# Altium schematic sheet [2] - MCU STM32F030CCTx.sch
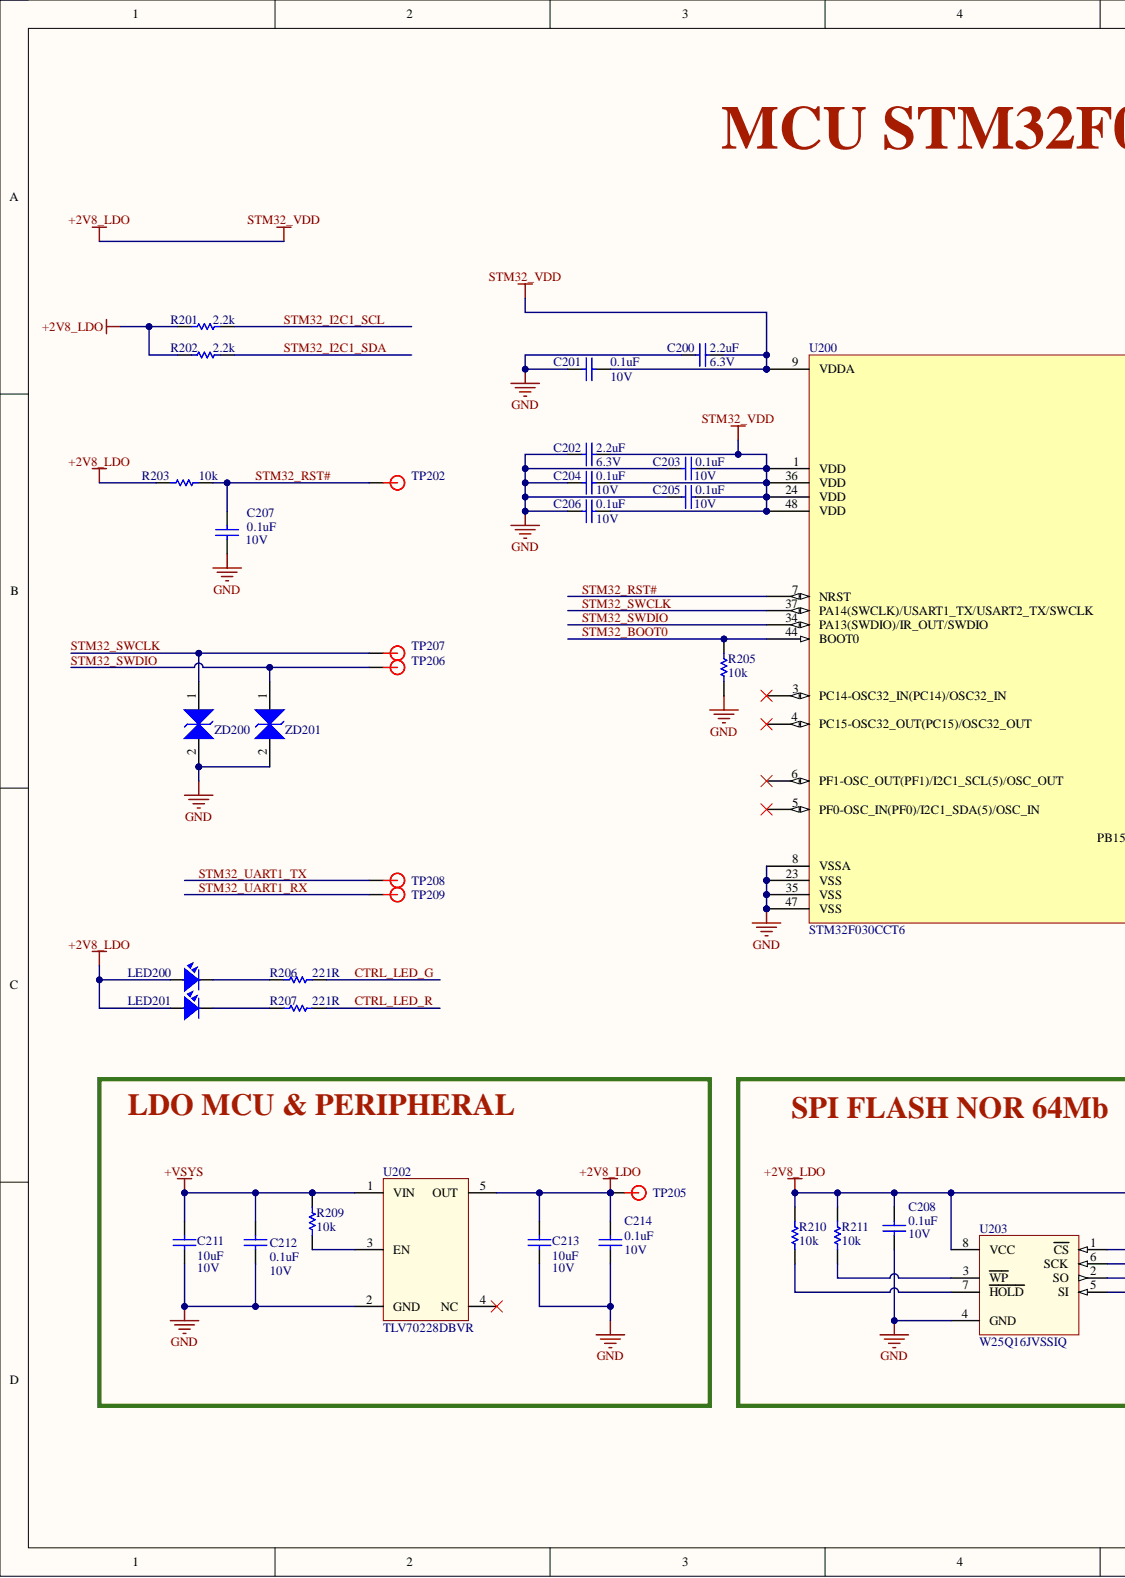
[diagram p0: [2] - MCU STM32F030CCTx.sch, left]
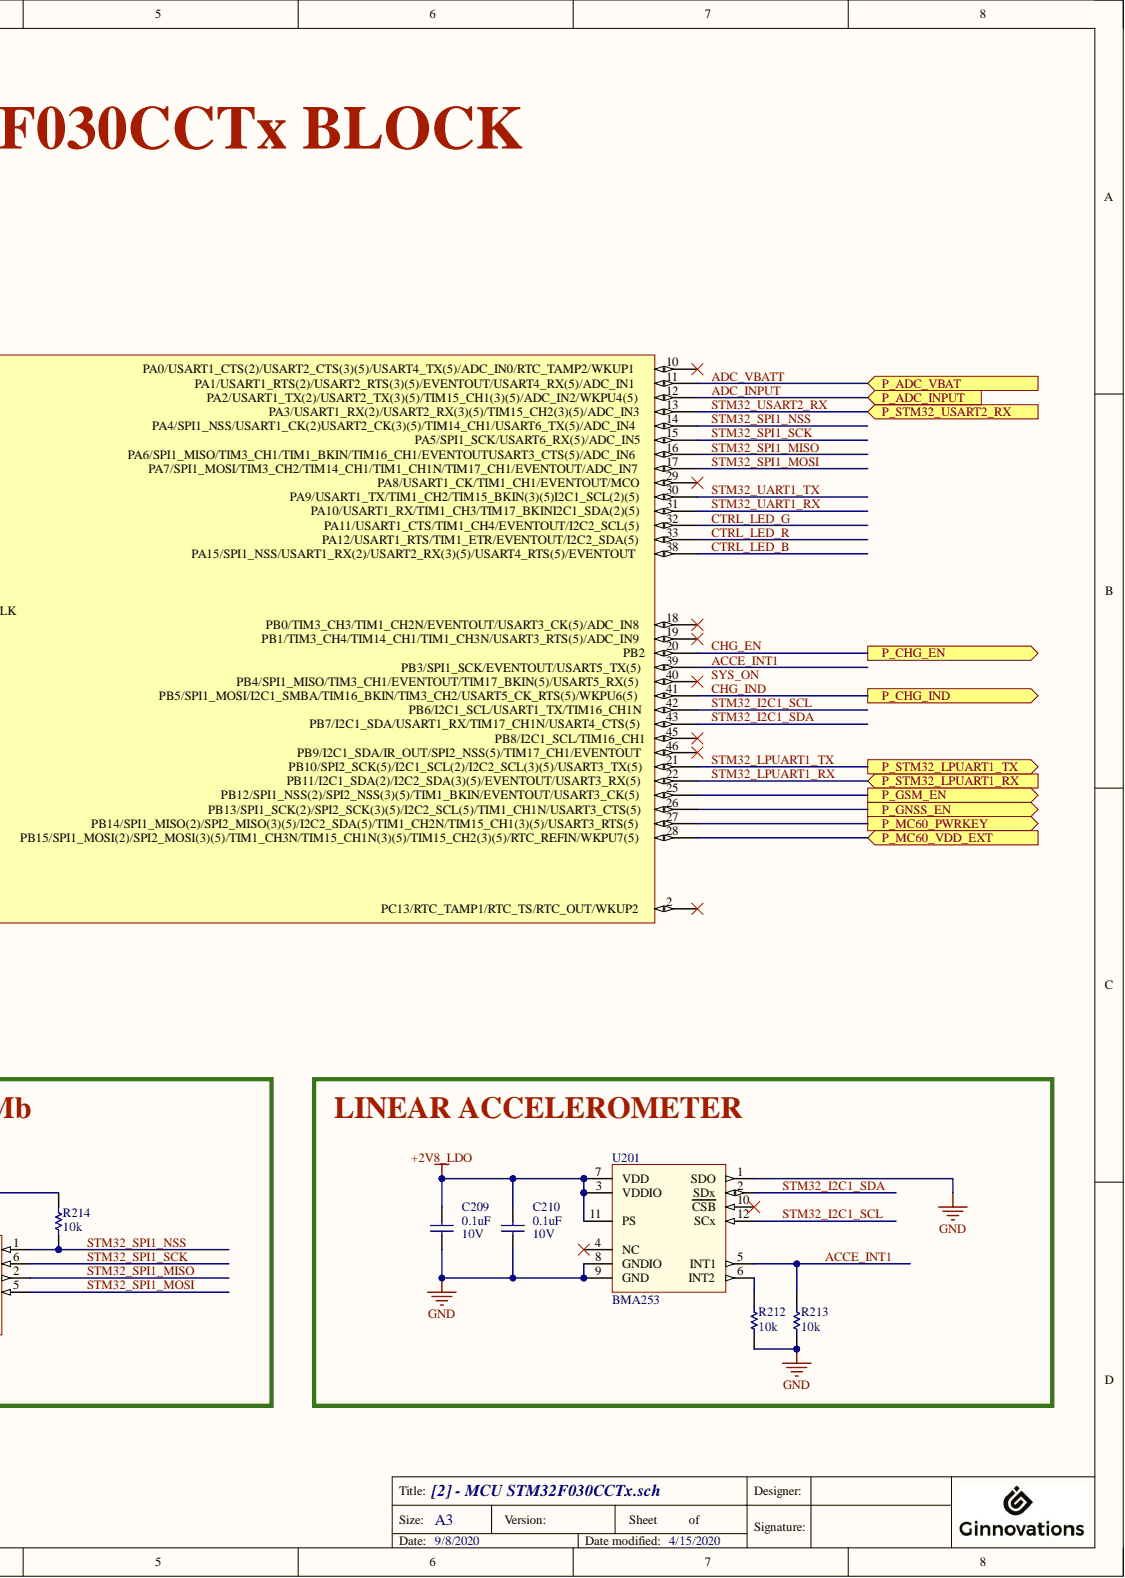
[diagram p1: [2] - MCU STM32F030CCTx.sch, right]

## Parts
C200  2.2uF  Value2: 6.3V  [C0402L]
C201  0.1uF  Value2: 10V  [C0402L]
C202  2.2uF  Value2: 6.3V  [C0402L]
C203  0.1uF  Value2: 10V  [C0402L]
C204  0.1uF  Value2: 10V  [C0402L]
C205  0.1uF  Value2: 10V  [C0402L]
C206  0.1uF  Value2: 10V  [C0402L]
C207  0.1uF  Value2: 10V  [C0402L]
C208  0.1uF  Value2: 10V  [C0402L]
C209  0.1uF  Value2: 10V  [C0402L]
C210  0.1uF  Value2: 10V  [C0402L]
C211  10uF  Value2: 10V  [C0603Z]
C212  0.1uF  Value2: 10V  [C0402L]
C213  10uF  Value2: 10V  [C0603Z]
C214  0.1uF  Value2: 10V  [C0402L]
LED200  LED  [LED0603]
LED201  LED  [LED0603]
R201  2.2k  [R0402L]
R202  2.2k  [R0402L]
R203  10k  [R0402L]
R205  10k  [R0402L]
R206  221R  [R0402L]
R207  221R  [R0402L]
R209  10k  [R0402L]
R210  10k  [R0402L]
R211  10k  [R0402L]
R212  10k  [R0402L]
R213  10k  [R0402L]
R214  10k  [R0402L]
TP202  2.54  [TESTPOINT 1.2mm]  (value not drawn)  (no BOM)
TP205  2.54  [TESTPOINT 1.2mm]  (value not drawn)  (no BOM)
TP206  2.54  [TESTPOINT 1.2mm]  (value not drawn)  (no BOM)
TP207  2.54  [TESTPOINT 1.2mm]  (value not drawn)  (no BOM)
TP208  2.54  [TESTPOINT 1.2mm]  (value not drawn)  (no BOM)
TP209  2.54  [TESTPOINT 1.2mm]  (value not drawn)  (no BOM)
U200  STM32F030CCT6  [LQFP48]
U201  BMA253  [BMA253]
U202  TLV70228DBVR  [SOT23-5Z]
U203  W25Q16JVSSIQ  [SOIC-8 (0.209")]
ZD200  3.3V  [SOD-923F1]  (value not drawn)  (no BOM)
ZD201  3.3V  [SOD-923F1]  (value not drawn)  (no BOM)

## Ports
P_ADC_INPUT, P_ADC_VBAT, P_CHG_EN, P_CHG_IND, P_GNSS_EN, P_GSM_EN, P_MC60_PWRKEY, P_MC60_VDD_EXT, P_STM32_LPUART1_RX, P_STM32_LPUART1_TX, P_STM32_USART2_RX

## Nets
+2V8_LDO: C200.2 C201.2 C202.2 C203.2 C204.2 C205.2 C206.2 C208.1 C209.1 C210.1 C213.1 C214.1 LED200.1 LED201.1 R201.2 R202.1 R203.2 R210.2 R211.2 R214.2 TP205.1 U200.1(VDD) U200.9(VDDA) U200.24(VDD) U200.36(VDD) U200.48(VDD) U201.3(VDDIO) U201.7(VDD) U201.11(PS) U202.5(OUT) U203.8(VCC)
+VSYS: C211.1 C212.1 R209.2 U202.1(VIN)
GND: C200.1 C201.1 C202.1 C203.1 C204.1 C205.1 C206.1 C207.1 C208.2 C209.2 C210.2 C211.2 C212.2 C213.2 C214.2 R205.1 R212.1 R213.1 U200.8(VSSA) U200.23(VSS) U200.35(VSS) U200.47(VSS) U201.1(SDO) U201.8(GNDIO) U201.9(GND) U202.2(GND) U203.4(GND) ZD200.2 ZD201.2
ACCE_INT1: R213.2 U200.39(PB3/SPI1_SCK/EVENTOUT/USART5_TX(5)) U201.5(INT1)
ADC_INPUT: U200.12(PA2/USART1_TX(2)/USART2_TX(3)(5)/TIM15_CH1(3)(5)/ADC_IN2/WKPU4(5)) ; port P_ADC_INPUT
ADC_VBATT: U200.11(PA1/USART1_RTS(2)/USART2_RTS(3)(5)/EVENTOUT/USART4_RX(5)/ADC_IN1) ; port P_ADC_VBAT
CHG_EN: U200.20(PB2) ; port P_CHG_EN
CHG_IND: U200.41(PB5/SPI1_MOSI/I2C1_SMBA/TIM16_BKIN/TIM3_CH2/USART5_CK_RTS(5)/WKPU6(5)) ; port P_CHG_IND
CTRL_LED_B: U200.38(PA15/SPI1_NSS/USART1_RX(2)/USART2_RX(3)(5)/USART4_RTS(5)/EVENTOUT)
CTRL_LED_G: R206.2 U200.32(PA11/USART1_CTS/TIM1_CH4/EVENTOUT/I2C2_SCL(5))
CTRL_LED_R: R207.2 U200.33(PA12/USART1_RTS/TIM1_ETR/EVENTOUT/I2C2_SDA(5))
P_GNSS_EN: U200.26(PB13/SPI1_SCK(2)/SPI2_SCK(3)(5)/I2C2_SCL(5)/TIM1_CH1N/USART3_CTS(5)) ; port P_GNSS_EN
P_GSM_EN: U200.25(PB12/SPI1_NSS(2)/SPI2_NSS(3)(5)/TIM1_BKIN/EVENTOUT/USART3_CK(5)) ; port P_GSM_EN
P_MC60_PWRKEY: U200.27(PB14/SPI1_MISO(2)/SPI2_MISO(3)(5)/I2C2_SDA(5)/TIM1_CH2N/TIM15_CH1(3)(5)/USART3_RTS(5)) ; port P_MC60_PWRKEY
P_MC60_VDD_EXT: U200.28(PB15/SPI1_MOSI(2)/SPI2_MOSI(3)(5)/TIM1_CH3N/TIM15_CH1N(3)(5)/TIM15_CH2(3)(5)/RTC_REFIN/WKPU7(5)) ; port P_MC60_VDD_EXT
STM32_BOOT0: R205.2 U200.44(BOOT0)
STM32_I2C1_SCL: R201.1 U200.42(PB6/I2C1_SCL/USART1_TX/TIM16_CH1N) U201.12(SCx)
STM32_I2C1_SDA: R202.2 U200.43(PB7/I2C1_SDA/USART1_RX/TIM17_CH1N/USART4_CTS(5)) U201.2(SDx)
STM32_LPUART1_RX: U200.22(PB11/I2C1_SDA(2)/I2C2_SDA(3)(5)/EVENTOUT/USART3_RX(5)) ; port P_STM32_LPUART1_RX
STM32_LPUART1_TX: U200.21(PB10/SPI2_SCK(5)/I2C1_SCL(2)/I2C2_SCL(3)(5)/USART3_TX(5)) ; port P_STM32_LPUART1_TX
STM32_RST#: C207.2 R203.1 TP202.1 U200.7(NRST)
STM32_SPI1_MISO: U200.16(PA6/SPI1_MISO/TIM3_CH1/TIM1_BKIN/TIM16_CH1/EVENTOUTUSART3_CTS(5)/ADC_IN6) U203.2(SO)
STM32_SPI1_MOSI: U200.17(PA7/SPI1_MOSI/TIM3_CH2/TIM14_CH1/TIM1_CH1N/TIM17_CH1/EVENTOUT/ADC_IN7) U203.5(SI)
STM32_SPI1_NSS: R214.1 U200.14(PA4/SPI1_NSS/USART1_CK(2)USART2_CK(3)(5)/TIM14_CH1/USART6_TX(5)/ADC_IN4) U203.1(CS)
STM32_SPI1_SCK: U200.15(PA5/SPI1_SCK/USART6_RX(5)/ADC_IN5) U203.6(SCK)
STM32_SWCLK: TP207.1 U200.37(PA14(SWCLK)/USART1_TX/USART2_TX/SWCLK) ZD200.1
STM32_SWDIO: TP206.1 U200.34(PA13(SWDIO)/IR_OUT/SWDIO) ZD201.1
STM32_UART1_RX: TP209.1 U200.31(PA10/USART1_RX/TIM1_CH3/TIM17_BKINI2C1_SDA(2)(5))
STM32_UART1_TX: TP208.1 U200.30(PA9/USART1_TX/TIM1_CH2/TIM15_BKIN(3)(5)I2C1_SCL(2)(5))
STM32_USART2_RX: U200.13(PA3/USART1_RX(2)/USART2_RX(3)(5)/TIM15_CH2(3)(5)/ADC_IN3) ; port P_STM32_USART2_RX
NetLED200_2: LED200.2 R206.1
NetLED201_2: LED201.2 R207.1
NetR209_1: R209.1 U202.3(EN)
NetR210_1: R210.1 U203.7(HOLD)
NetR211_1: R211.1 U203.3(WP)
NetR212_2: R212.2 U201.6(INT2)
SYS_ON:

Not connected: U200 2(PC13/RTC_TAMP1/RTC_TS/RTC_OUT/WKUP2) 3(PC14-OSC32_IN(PC14)/OSC32_IN) 4(PC15-OSC32_OUT(PC15)/OSC32_OUT) 5(PF0-OSC_IN(PF0)/I2C1_SDA(5)/OSC_IN) 6(PF1-OSC_OUT(PF1)/I2C1_SCL(5)/OSC_OUT) 10(PA0/USART1_CTS(2)/USART2_CTS(3)(5)/USART4_TX(5)/ADC_IN0/RTC_TAMP2/WKUP1) 18(PB0/TIM3_CH3/TIM1_CH2N/EVENTOUT/USART3_CK(5)/ADC_IN8) 19(PB1/TIM3_CH4/TIM14_CH1/TIM1_CH3N/USART3_RTS(5)/ADC_IN9) 29(PA8/USART1_CK/TIM1_CH1/EVENTOUT/MCO) 40(PB4/SPI1_MISO/TIM3_CH1/EVENTOUT/TIM17_BKIN(5)/USART5_RX(5)) 45(PB8/I2C1_SCL/TIM16_CH1) 46(PB9/I2C1_SDA/IR_OUT/SPI2_NSS(5)/TIM17_CH1/EVENTOUT); U201 4(NC) 10(CSB); U202 4(NC)

## Library data of the parts
C200 C202 = C0402: CAP CER 0402; Manufacturer: Murata Electronics; Manufacturer Part Number: GRM155R60J225KE95D; Code HW: EAPCA00023; Comment Description: CAP CER 2.2UF 6.3V X5R 0402; Tolerance: 10%; Types: Capacitor; Case / Package: 0402; Component Kind: Standard; Ginno Items Number Stock: N/A; Ginno Part Number: N/A; Mounting Type: Surface Mount; Price: N/A; Value: 2.2uF; Library Name: Xphone Rev2.4.SCHLIB
C201 C203-C210 C212 C214 = C0402: CAP CER 0402; Manufacturer: Murata Electronics; Manufacturer Part Number: GRM155R71A104KA01D; Code HW: EAPCA00006; Comment Description: Multilayer Ceramic Capacitors MLCC - SMD/SMT 0402 0.1uF 10volts X7R 10%; Tolerance: 10%; Types: Capacitor; Case / Package: 0402; Component Kind: Standard; Ginno Items Number Stock: N/A; Ginno Part Number: N/A; Mounting Type: Surface Mount; Price: N/A; Value: 0.1uF; Library Name: Xphone Rev2.4.SCHLIB
C211 C213 = C0603: CAP CER 0603; Manufacturer: Taiyo Yuden; Manufacturer Part Number: LMK107BBJ106MALT; Code HW: EAPCA00025; Comment Description: CAP CER 10UF 10V X5R 0603; Tolerance: 20%; Types: Capacitor; Case / Package: 0603; Component Kind: Standard; Ginno Items Number Stock: N/A; Ginno Part Number: N/A; Mounting Type: Surface Mount; Price: N/A; Value: 10uF; Library Name: Xphone Rev2.4.SCHLIB
LED200 = LED SMD: Manufacturer: Lite-On Inc.; Manufacturer Part Number: HQ19-2132UBGC82; Code HW: EAPLE00005; Comment Description: LED GREEN CLEAR SMD; Value: LED G 0603; Case / Package: 0603; Component Kind: Standard; Ginno Items Number Stock: N/A; Ginno Part Number: N/A; Library Name: LIBRARY.IntLib; Mounting Type: Surface Mount; Price: N/A; Tolerance: N/A; Types: LED; Value2: N/A
LED201 = LED SMD: Manufacturer: Lite-On Inc.; Manufacturer Part Number: HQ19-2132SURC62; Code HW: EAPLE00006; Comment Description: LED RED CLEAR SMD; Value: LED R 0603; Case / Package: 0603; Component Kind: Standard; Ginno Items Number Stock: N/A; Ginno Part Number: N/A; Library Name: LIBRARY.IntLib; Mounting Type: Surface Mount; Price: N/A; Tolerance: N/A; Types: LED; Value2: N/A
R201 R202 = R0402: RES SMD 0402; Manufacturer: Panasonic; Manufacturer Part Number: ERJ-2GEJ222X; Code HW: EAPRE00012; Comment Description: Thick Film Resistors - SMD 1/16watt 2.2Kohms 5%; Ginno Items Number Stock: NA; Tolerance: 5%; Types: Resistor; Value2: 1/10W; Case / Package: 0402; Component Kind: Standard; Ginno Part Number: N/A; Mounting Type: Surface Mount; Price: N/A; Value: 2.2k
  R201: Library Name: Ginno.SCHLIB
  R202: Library Name: Xphone Rev2.4.SCHLIB
R203 R205 R209-R214 = R0402: RES SMD 0402; Manufacturer: Panasonic; Manufacturer Part Number: ERJ-2RKF1002X; Code HW: EAPRE00013; Comment Description: RES SMD 10K OHM 1% 1/10W 0402; Tolerance: 1%; Types: Resistor; Value2: 1/10W; Case / Package: 0402; Component Kind: Standard; Ginno Items Number Stock: N/A; Ginno Part Number: N/A; Mounting Type: Surface Mount; Price: N/A; Value: 10k; Library Name: Ginno.SCHLIB
R206 R207 = R0402: RES SMD 0402; Manufacturer: Panasonic; Manufacturer Part Number: ERJ-2GEJ221X; Code HW: EAPRE00017; Comment Description: RES SMD 220 OHM 5% 1/10W 0402; Ginno Items Number Stock: NA; Tolerance: 1%; Types: Resistor; Value2: 1/16W; Case / Package: 0402; Component Kind: Standard; Ginno Part Number: N/A; Library Name: Ginno.SCHLIB; Mounting Type: Surface Mount; Price: N/A; Value: 221R
TP202 TP205-TP209 = TESTPOINT 5000: TEST POINT PC MINI .040"D RED; Types: TP; Ginno Items Number Stock: N/A; Ginno Part Number: N/A; Library Name: mLib.SchLib; Manufacturer: N/A; Manufacturer Part Number: N/A; Mounting Type: Surface Mount; Price: N/A; Tolerance: N/A; Value: N/A; Value2: N/A
U200 = STM32F030CCT6: STMICROELECTRONICS         STM32F030CCT6             32 Bit Microcontroller, Value Line, ARM Cortex-M0, 48 MHz, 256 KB, 32 KB, 48 Pins, LQFP; Manufacturer Part Number: STM32F030CCT6TR; Code HW: EAPIC00028; Comment Description: IC MCU 32BIT 256KB FLASH 48LQFP; Types: IC; Value: STM32F030CCT6TR; Case / Package: 48-LQFP; Component Kind: Standard; Ginno Items Number Stock: N/A; Ginno Part Number: N/A; Manufacturer: STMicroelectronics; Mounting Type: Surface Mount; Price: N/A; Tolerance: N/A; Value2: N/A; Library Name: GTRACKER REV1.7.SCHLIB
U201 = BMA253: ACCELERATION DIGITAL, TRIAXIAL S; Manufacturer: Bosch Sensortec; Code HW: EAPIC00024; Comment Description: ACCEL 2-16G I2C/SPI 12LGA; Ginno Items Number Stock: NA; Types: IC; Case / Package: 12-VFLGA; Component Kind: Standard; Ginno Part Number: N/A; Manufacturer Part Number: BMA253; Mounting Type: Surface Mount; Price: N/A; Tolerance: N/A; Value: BMA253; Value2: N/A; Library Name: Gtracker.SCHLIB
U202 = TLV70233DBVR: IC REG LDO 2.8V 0.3A SOT23-5; Manufacturer: Texas Instruments; Code HW: EAPIC00029; Comment Description: IC REG LINEAR 2.8V 300MA SOT23-5; Ginno Items Number Stock: NA; Case / Package: SOT-23-5; Component Kind: Standard; Ginno Part Number: N/A; Manufacturer Part Number: TLV70228DBVR; Mounting Type: Surface Mount; Price: N/A; Tolerance: N/A; Types: IC; Value: TLV70228DBVR; Value2: N/A; Library Name: GTRACKER REV1.1 COPY.SCHLIB
U203 = AT25SF161: IC FLASH 64M SPI 133MHZ 8SOIC; Manufacturer: Winbond Electronics; Code HW: EAPIC00031; Comment Description: IC FLASH 16M SPI 133MHZ 8SOIC; Case / Package: 8-SOIC; Component Kind: Standard; Ginno Items Number Stock: N/A; Ginno Part Number: N/A; Manufacturer Part Number: W25Q16JVSSIQ; Mounting Type: Surface Mount; Price: N/A; Tolerance: N/A; Types: IC; Value: W25Q16JVSSIQ; Value2: N/A; Library Name: Gtracker.SCHLIB
ZD200 ZD201 = UCLAMP2511T.TCT: Diode TVS Single Bi-Dir 2.5V 40W 2-Pin SLP1006P2 T/R; Manufacturer: ON Semiconductor; Manufacturer Part Number: ESD9X3.3ST5G; Code HW: EAPVA00003; Comment Description: Micro−Packaged Diodes for ESD Protection; Case / Package: SOD923; Ginno Items Number Stock: N/A; Ginno Part Number: N/A; Mounting Type: Surface Mount; Price: N/A; Tolerance: N/A; Types: TVS; Value: 3.3V; Library Name: Ginno.SCHLIB
